# Altium schematic sheet MCISO-S01-V00-Master.SchDoc
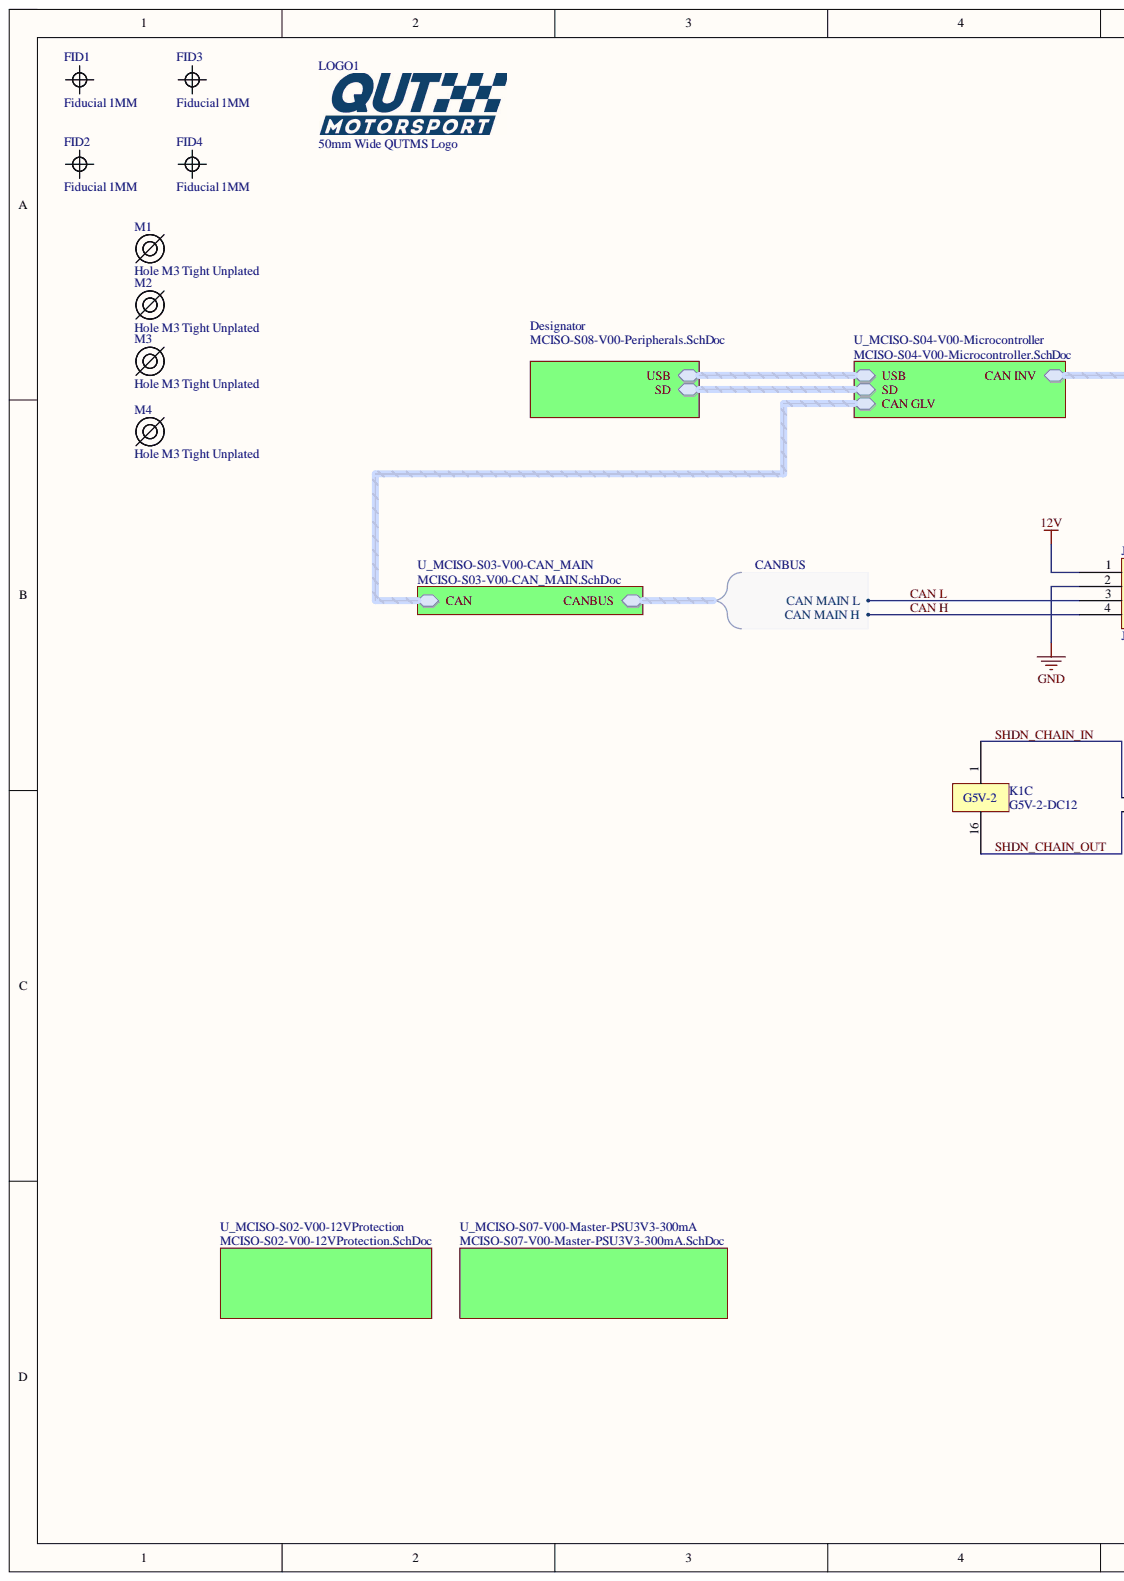
[diagram p0: MCISO-S01-V00-Master.SchDoc, left]
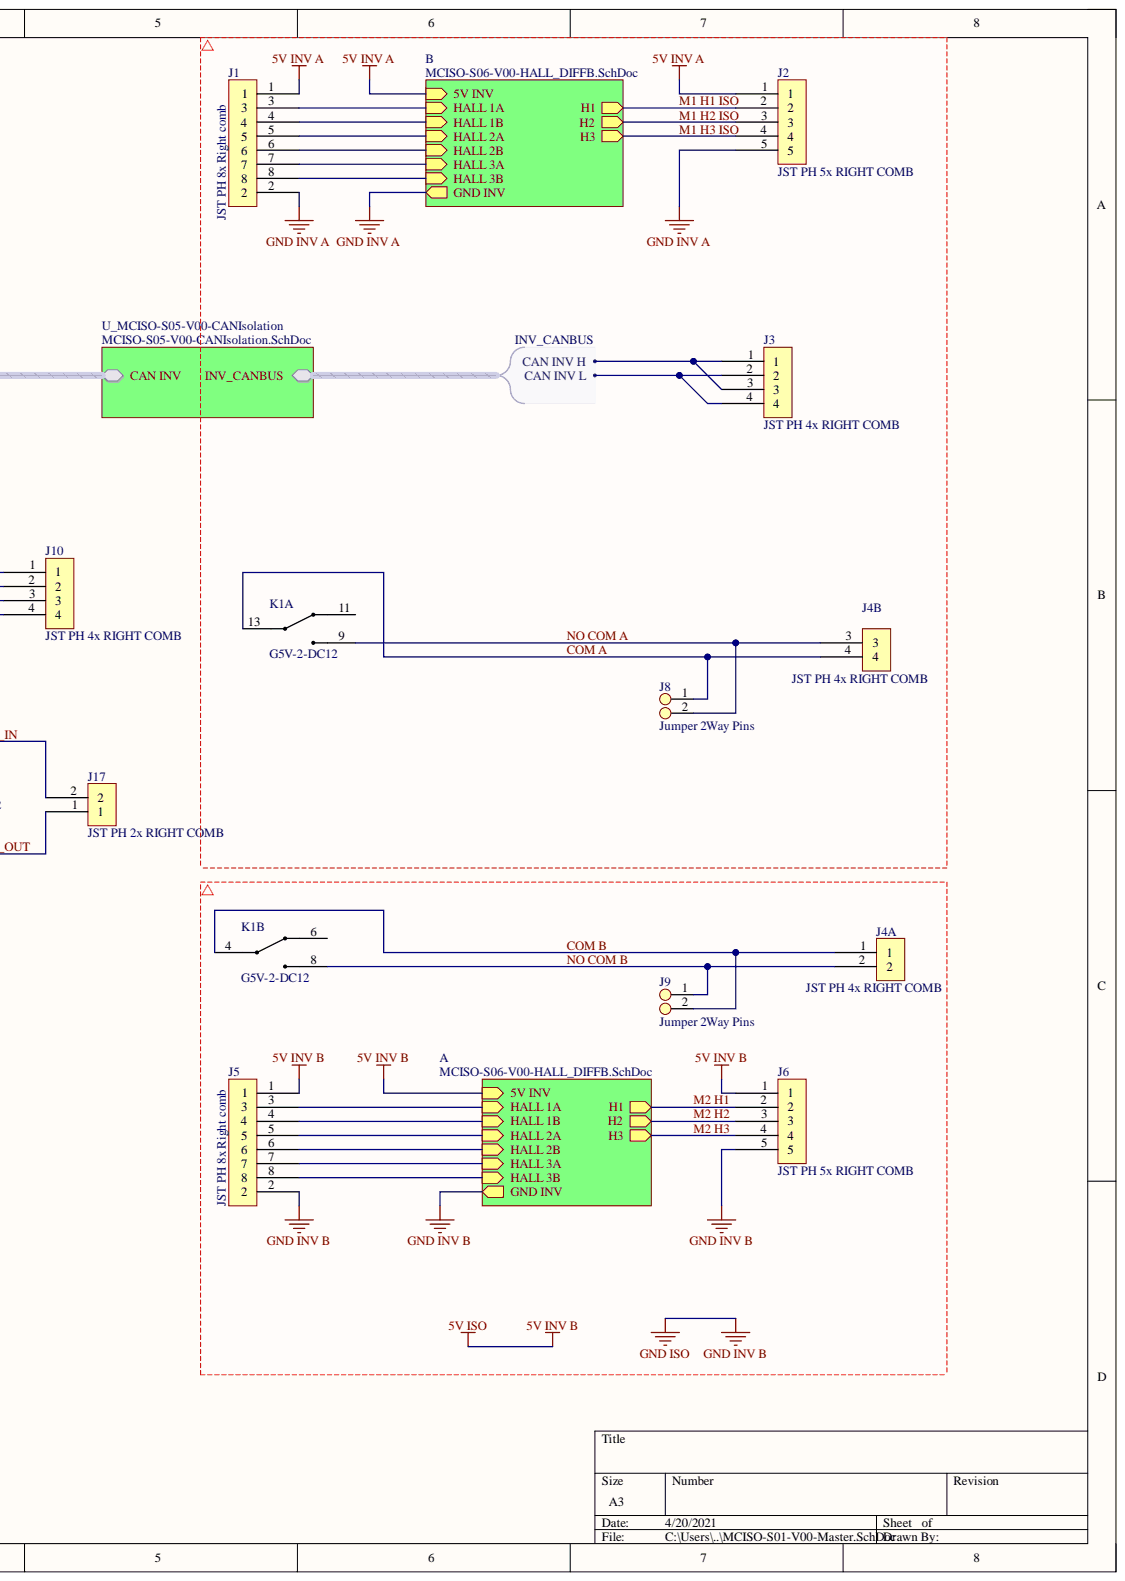
[diagram p1: MCISO-S01-V00-Master.SchDoc, right]

## Parts
FID1  Fiducial 1MM  [FIDUCIAL 1MM]  (no BOM)
FID2  Fiducial 1MM  [FIDUCIAL 1MM]  (no BOM)
FID3  Fiducial 1MM  [FIDUCIAL 1MM]  (no BOM)
FID4  Fiducial 1MM  [FIDUCIAL 1MM]  (no BOM)
J1  JST PH 8x Right comb  [JST PH 8x RIGHT SMD]
J2  JST PH 5x RIGHT COMB  [JST PH 5x RIGHT COMB]
J3  JST PH 4x RIGHT COMB  [JST PH 4x RIGHT COMB]
J4  JST PH 4x RIGHT COMB  [JST PH 4x RIGHT COMB]  (parts A,B)
J5  JST PH 8x Right comb  [JST PH 8x RIGHT SMD]
J6  JST PH 5x RIGHT COMB  [JST PH 5x RIGHT COMB]
J8  Jumper 2Way Pins  [Jumper_2Way_Pin]
J9  Jumper 2Way Pins  [Jumper_2Way_Pin]
J10  JST PH 4x RIGHT COMB  [JST PH 4x RIGHT COMB]
J17  JST PH 2x RIGHT COMB  [JST PH 2x RIGHT COMB]
K1  G5V-2-DC12  [G5V-2]  (parts A,B,C)
LOGO1  50mm Wide QUTMS Logo  [QUTMS LOGO 10MM]  (no BOM)
M1  Hole M3 Tight Unplated  [M3.2 UNPLATED]
M2  Hole M3 Tight Unplated  [M3.2 UNPLATED]
M3  Hole M3 Tight Unplated  [M3.2 UNPLATED]
M4  Hole M3 Tight Unplated  [M3.2 UNPLATED]

## Nets
5V INV A: J1.1 J2.1
5V INV B: J5.1 J6.1
12V: J10.1
GND: J10.2
GND INV A: J1.2 J2.5
GND INV B: J5.2 J6.5
CAN H: J10.4
CAN L: J10.3
COM A: J4.4 J8.1 K1.13
COM B: J4.1 J9.2 K1.4
M1 H1 ISO: J2.2
M1 H2 ISO: J2.3
M1 H3 ISO: J2.4
M2 H1: J6.2
M2 H2: J6.3
M2 H3: J6.4
NO COM A: J4.3 J8.2 K1.9
NO COM B: J4.2 J9.1 K1.8
SHDN_CHAIN_IN: J17.2 K1.1
SHDN_CHAIN_OUT: J17.1 K1.16
NetJ1_3: J1.3
NetJ1_4: J1.4
NetJ1_5: J1.5
NetJ1_6: J1.6
NetJ1_7: J1.7
NetJ1_8: J1.8
NetJ3_1: J3.1 J3.3
NetJ3_2: J3.2 J3.4
NetJ5_3: J5.3
NetJ5_4: J5.4
NetJ5_5: J5.5
NetJ5_6: J5.6
NetJ5_7: J5.7
NetJ5_8: J5.8
NetK1_6: K1.6
NetK1_11: K1.11
CAN GLV.RX:
CAN GLV.TX:
CAN INV.RX:
CAN INV.TX:
SD.SD_CLK:
SD.SD_CMD:
SD.SD_D0:
SD.SD_D1:
SD.SD_D2:
SD.SD_D3:
USB.USB_D6:
USB.USB_DM:
USB.USB_DP:

## Library data of the parts
J4 = JST PH 4x RIGHT COMB_1: Component Kind: Standard; Library Name: QUTMS-MCISOLibrary.SchLib
J8 J9 = Jumper 2Way Side Pins: Component Kind: Standard; Description: Jumper 2Way Side Pins; Library Name: QUTMS-MCISOLibrary.SchLib
K1 = G5V-2-DC12: General Purpose Relay DPDT (2 Form C) Through Hole, 12V, 2A; Component Kind: Standard; Library Name: QUTMS-MCISOLibrary.SchLib
LOGO1 = QUTMS Logo 50mm: Description: 50mm Wide QUTMS Logo; Library Name: QUTMS-MCISOLibrary.SchLib
M1-M4 = Hole M3 Tight Unplated: M3.2 Hole, No Plating; Component Kind: Standard; Library Name: QUTMS-MCISOLibrary.SchLib
FID1-FID4 = Fiducial 1MM: Description: Fiducial 1MM; Library Name: QUTMS-MCISOLibrary.SchLib
J1 J5 = JST PH 8x Right comb: Component Kind: Standard; Library Name: QUTMS-MCISOLibrary.SchLib
J2 J6 = JST PH 5x RIGHT COMB: Component Kind: Standard; Library Name: QUTMS-MCISOLibrary.SchLib
J3 J10 = JST PH 4x RIGHT COMB: Component Kind: Standard; Library Name: QUTMS-MCISOLibrary.SchLib
J17 = JST PH 2x RIGHT COMB: Component Kind: Standard; Library Name: QUTMS-MCISOLibrary.SchLib
